# Altium schematic sheet PiJack -Microchip.SchDoc
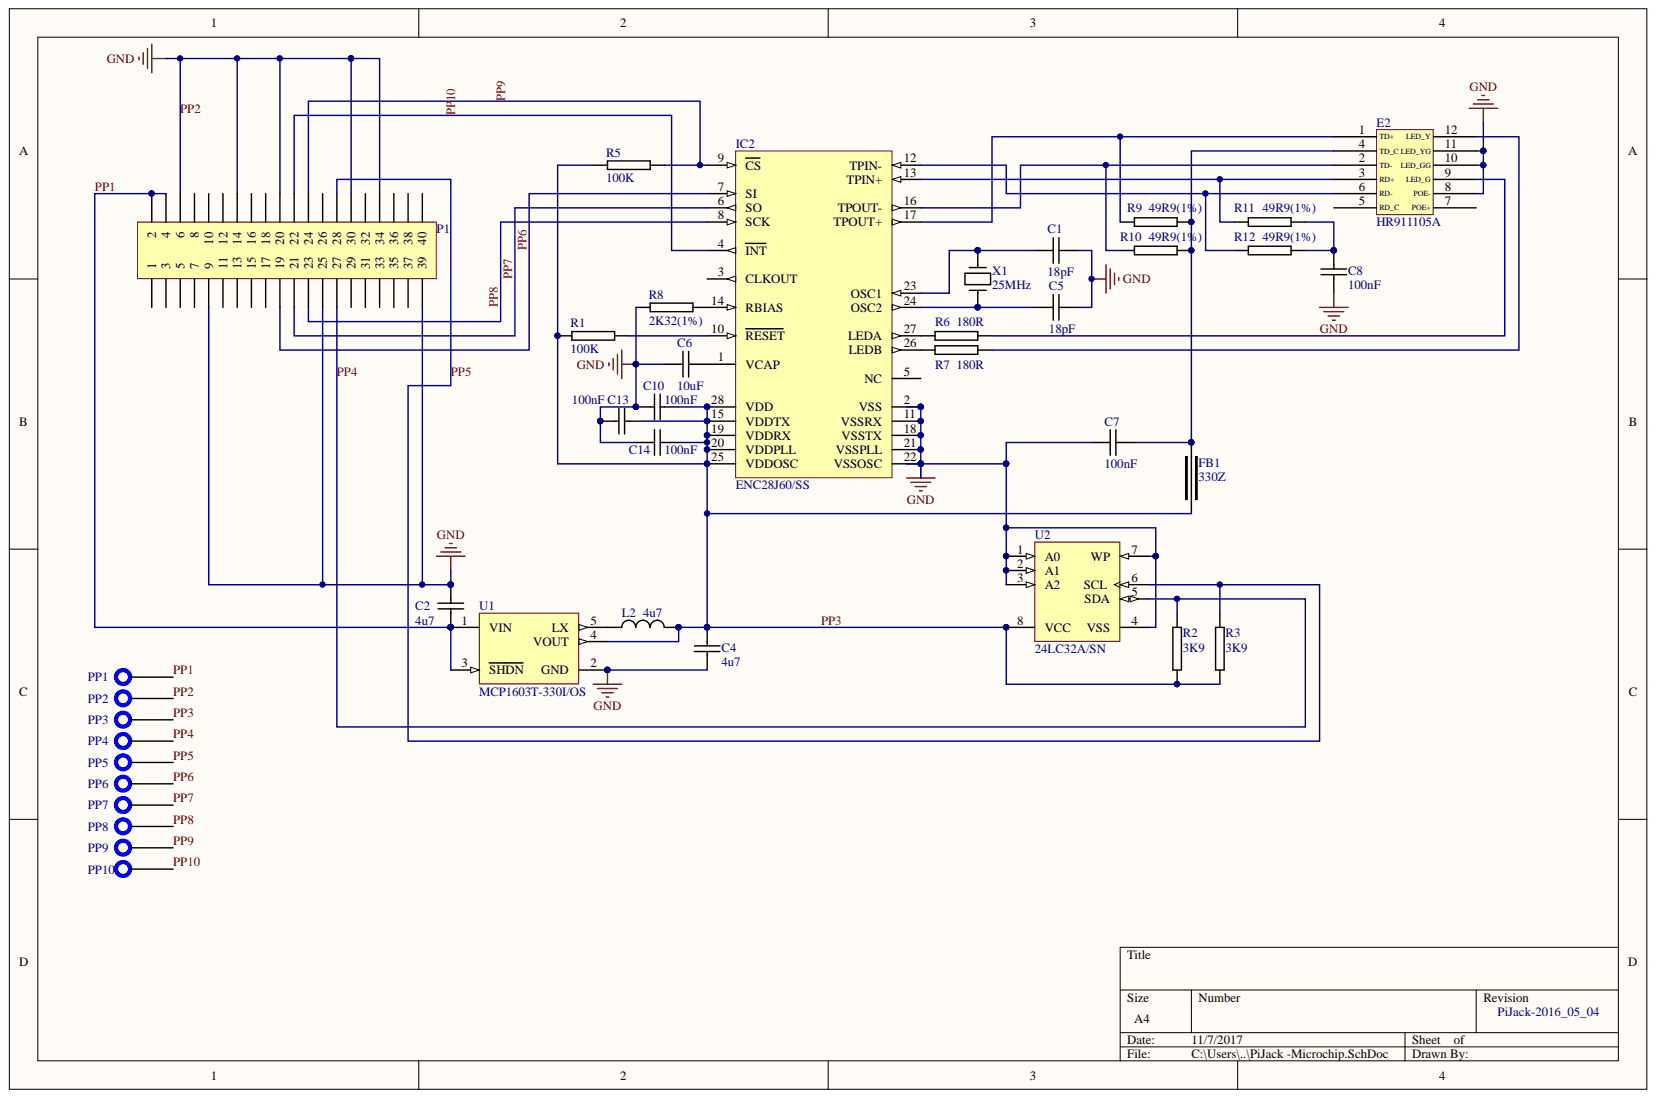
[diagram p0: PiJack -Microchip.SchDoc]

## Parts
C1  18pF  [0603C-GENERIC]
C2  4u7  [0603C-GENERIC]
C4  4u7  [0603C-GENERIC]
C5  18pF  [0603C-GENERIC]
C6  10uF  [0805C-GENERIC]
C7  100nF  [0603C-GENERIC]
C8  100nF  [0603C-GENERIC]
C10  100nF  Reel: C13  [0603C-GENERIC]
C13  100nF  Reel: C13  [0603C-GENERIC]
C14  100nF  [0603C-GENERIC]
E2  HR911105A  [HLJ6115ANL]
FB1  330Z  [0805FB-330Z]
IC2  ENC28J60/SS  [SSOP-SS28_N]
L2  4u7  [VLS2010]
P1  Header 20X2  [HDR2X20]  (value not drawn)
PP1  TEST_POINT  [TEST_POINT]  (value not drawn)
PP2  TEST_POINT  [TEST_POINT]  (value not drawn)
PP3  TEST_POINT  [TEST_POINT]  (value not drawn)
PP4  TEST_POINT  [TEST_POINT]  (value not drawn)
PP5  TEST_POINT  [TEST_POINT]  (value not drawn)
PP6  TEST_POINT  [TEST_POINT]  (value not drawn)
PP7  TEST_POINT  [TEST_POINT]  (value not drawn)
PP8  TEST_POINT  [TEST_POINT]  (value not drawn)
PP9  TEST_POINT  [TEST_POINT]  (value not drawn)
PP10  TEST_POINT  [TEST_POINT]  (value not drawn)
R1  100K  [0603R-100K]
R2  3K9  [0603R-GENERIC]
R3  3K9  [0603R-GENERIC]
R5  100K  [0603R-100K]
R6  180R  [0603R-180]
R7  180R  [0603R-180]
R8  2K32(1%)  [0603R-GENERIC]
R9  49R9(1%)  [0805R-47K]
R10  49R9(1%)  [0805R-47K]
R11  49R9(1%)  [0805R-47K]
R12  49R9(1%)  [0805R-47K]
U1  MCP1603T-330I/OS  [TSOT-OS5_N]
U2  24LC32A/SN  [SOIC-SN8_N]
X1  25MHz  [XTAL-RU49/4]

## Nets
PP1: C2.2 P1.2 P1.4 PP1.1 U1.1(VIN) U1.3(SHDN)
PP2: C1.2 C2.1 C4.2 C5.2 C6.1 C7.1 C8.2 C10.1 C13.1 C14.1 E2.8(POE-) E2.10(LED_GG) E2.11(LED_YG) IC2.2(VSS) IC2.11(VSSRX) IC2.18(VSSTX) IC2.21(VSSPLL) IC2.22(VSSOSC) P1.6 P1.9 P1.14 P1.20 P1.25 P1.30 P1.34 P1.39 PP2.1 R8.1 U1.2(GND) U2.1(A0) U2.2(A1) U2.3(A2) U2.4(VSS) U2.7(WP)
PP3: C4.1 C10.2 C13.2 C14.2 FB1.2 IC2.15(VDDTX) IC2.19(VDDRX) IC2.20(VDDPLL) IC2.25(VDDOSC) IC2.28(VDD) L2.2 PP3.1 R1.1 R2.2 R3.2 R5.1 U1.4(VOUT) U2.8(VCC)
PP4: P1.27 PP4.1 R2.1 U2.5(SDA)
PP5: P1.28 PP5.1 R3.1 U2.6(SCL)
PP6: IC2.7(SI) P1.19 PP6.1
PP7: IC2.6(SO) P1.21 PP7.1
PP8: IC2.8(SCK) P1.23 PP8.1
PP9: IC2.9(CS) P1.24 PP9.1 R5.2
PP10: IC2.4(INT) P1.22 PP10.1
NetC1_1: C1.1 IC2.23(OSC1) X1.1
NetC5_1: C5.1 IC2.24(OSC2) X1.2
NetC6_2: C6.2 IC2.1(VCAP)
NetC7_2: C7.2 E2.4(TD_C) FB1.1 R9.2 R10.2
NetC8_1: C8.1 R11.2 R12.2
NetE2_1: E2.1(TD+) IC2.17(TPOUT+) R9.1
NetE2_2: E2.2(TD-) IC2.16(TPOUT-) R10.1
NetE2_3: E2.3(RD+) IC2.13(TPIN+) R11.1
NetE2_6: E2.6(RD-) IC2.12(TPIN-) R12.1
NetE2_9: E2.9(LED_G) R6.2
NetE2_12: E2.12(LED_Y) R7.2
NetIC2_10: IC2.10(RESET) R1.2
NetIC2_14: IC2.14(RBIAS) R8.2
NetIC2_26: IC2.26(LEDB) R7.1
NetIC2_27: IC2.27(LEDA) R6.1
NetL2_1: L2.1 U1.5(LX)

Not connected: E2 5(RD_C) 7(POE+); IC2 3(CLKOUT) 5(NC); P1 1 3 5 7 8 10 11 12 13 15 16 17 18 26 29 31 32 33 35 36 37 38 40

## Library data of the parts
C1 C2 C4 C5 C7 C8 C14 = 0603C-4U7-10V-X5R-TDK: CAPACITOR 0603 CERAMIC; Manufacturer: TDK Corporation; Manufacturer Part Number: C1608X5R1A475K; Capacitance: 4.7µF; Reel: C13; Temperature Coefficient: X5R; Tolerance: ±10%; Voltage - Rated: 10V; Category: Capacitors; Component Kind: Standard; Features: General Purpose; Lead Spacing: -; Library Name: SMD Capacitors 0603.SchLib; Mounting Type: Surface Mount, MLCC; Operating Temperature: -55°C ~ 85°C; Package / Case: 0603 (1608 metric); Packaging: Tape & Reel (TR); RoHS: RoHS Compliant; Supplier: Digi-Key; Supplier 1: Digi-Key; Supplier Part Number: 445-5170-2-ND; Supplier Part Number 1: 445-5170-2-ND; Thickness: 0.80mm
C6 = 0805C-10UF-16V-X5R-TDK: CAPACITOR 0805 CERAMIC; Manufacturer: TDK Corporation; Manufacturer Part Number: C2012X5R1C106M; REEL: C63; Component Kind: Standard; Library Name: SMD Capacitors 0805.SchLib; Supplier 1: Digi-Key; Supplier Part Number 1: 445-4115-2-ND
C10 C13 = 0603C-4U7-10V-X5R-TDK: CAPACITOR 0603 CERAMIC; Manufacturer: TDK Corporation; Manufacturer Part Number: C1608X5R1A475K; Capacitance: 4.7µF; Temperature Coefficient: X5R; Tolerance: ±10%; Voltage - Rated: 10V; Category: Capacitors; Component Kind: Standard; Features: General Purpose; Lead Spacing: -; Library Name: SMD Capacitors 0603.SchLib; Mounting Type: Surface Mount, MLCC; Operating Temperature: -55°C ~ 85°C; Package / Case: 0603 (1608 metric); Packaging: Tape & Reel (TR); RoHS: RoHS Compliant; Supplier: Digi-Key; Supplier 1: Digi-Key; Supplier Part Number: 445-5170-2-ND; Supplier Part Number 1: 445-5170-2-ND; Thickness: 0.80mm
E2 = HLJ-6115ANL: Component Kind: Standard; Library Name: PiJack.SchLib
FB1 = 0805FB-330Z: FERRITE BEAD 330 OHM 2.5A; Reel: F4; Component Kind: Standard; Library Name: SMD Magnetics.SchLib
IC2 = ENC28J60/SS: Stand-Alone Ethernet Controller with SPI Interface, 28-Pin SSOP; Code_IPC: SOP65P780-28; Code_JEDEC: MS-150; Component Kind: Standard; ComponentLink1Description: Datasheet; ComponentLink1URL: http://ww1.microchip.com/downloads/en/DeviceDoc/39662c.pdf; ComponentLink2Description: Packaging Specification; ComponentLink2URL: http://www.microchip.com/stellent/groups/techpub_sg/documents/packagingspec/en012702.pdf; ComponentLink3Description: Microchip.com Home; ComponentLink3URL: http://www.microchip.com/; DatasheetVersion: RevC, Jan-2008; Library Name: Microchip Interface.IntLib; PackageDescription: 28-Lead Plastic Shrink Small Outline (SS) - 5.3mm Body [SSOP]; PackageReference: SSOP-SS28; PackageVersion: revBB, Aug-2009; Published: 29-Jan-2009; Supplier 1: Digi-Key; Supplier 2: Farnell; Supplier Part Number 1: ENC28J60/SS-ND; Supplier Part Number 2: 1084620; Publisher: Altium Limited
L2 = 4U7-VLS3012-TDK: TDK-VLS3012-4u7; Manufacturer: TDK Corporation; Manufacturer Part Number: VLS3012T-4R7M1R0; Reel: L3; Component Kind: Standard; Supplier 1: Digi-Key; Supplier Part Number 1: 445-3686-6-ND; Library Name: SMD Magnetics.SchLib
P1 = Header 20X2: Header, 20-Pin, Dual row; Component Kind: Standard; LatestRevisionDate: 17-Jul-2002; LatestRevisionNote: Re-released for DXP Platform.; Library Name: Miscellaneous Connectors.IntLib; Publisher: Altium Limited
R1 R5 = 0603R-100K: GENERIC RESISTOR 0603; Manufacturer: Stackpole Electronics Inc; Manufacturer Part Number: RMCF 1/16 100K 1% R; Power (Watts): 0.1W, 1/10W; REEL: R47; Temperature Coefficient: ±100ppm/°C; Tolerance: ±1%; Case: 0603 (1608 metric); Category: Resistors; Component Kind: Standard; Composition: Thick Film; Lead Style: Surface Mount (SMD - SMT); Library Name: SMD Resistors 0603.SchLib; Packaging: Cut Tape (CT); Resistance In Ohms: 100K; RoHS: RoHS Compliant; Supplier: Digi-Key; Supplier 1: Digi-Key; Supplier Part Number: RMCF1/16100KFRCT-ND; Supplier Part Number 1: RMCF0603FT100KTR-ND
R2 R3 = 0603R-3K6: GENERIC RESISTOR 0603; Manufacturer: Stackpole Electronics Inc; Manufacturer Part Number: RMC 1/16 3.6K 5% R; Component Kind: Standard; Library Name: SMD Resistors 0603.SchLib; Supplier 1: Digi-Key; Supplier Part Number 1: RMC1/163.6KJR-ND
R6 R7 = 0603R-180: GENERIC RESISTOR 0603; Manufacturer: Stackpole Electronics Inc; Manufacturer Part Number: RMC 1/16 180 1% R; Power (Watts): 0.1W, 1/10W; Resistance In Ohms: 180; Temperature Coefficient: ±100ppm/°C; Tolerance: ±1%; Case: 0603 (1608 metric); Category: Resistors; Component Kind: Standard; Composition: Thick Film; Lead Style: Surface Mount (SMD - SMT); Library Name: SMD Resistors 0603.SchLib; Packaging: Tape & Reel (TR); RoHS: RoHS Compliant; Supplier: Digi-Key; Supplier 1: Digi-Key; Supplier Part Number: RMC1/16180FR-ND; Supplier Part Number 1: RMC1/16180FR-ND
R8 = 0603R-2K49: GENERIC RESISTOR 0603; Manufacturer: Stackpole Electronics Inc; Manufacturer Part Number: RMCF 1/16 2.49K 1% R; Power (Watts): 0.1W, 1/10W; REEL: R83; Resistance In Ohms: 2.49K; Temperature Coefficient: ±100ppm/°C; Case: 0603 (1608 metric); Category: Resistors; Component Kind: Standard; Composition: Thick Film; Lead Style: Surface Mount (SMD - SMT); Library Name: SMD Resistors 0603.SchLib; Packaging: Tape & Reel (TR); RoHS: RoHS Compliant; Supplier: Digi-Key; Supplier 1: Digi-Key; Supplier Part Number: RMCF1/162.49KFRTR-ND; Supplier Part Number 1: RMCF0603FT2K49TR-ND; Tolerance: ±1%
R9-R12 = 0603R-49R9: GENERIC RESISTOR 0603; Component Kind: Standard; Library Name: SMD Resistors 0603.SchLib; Supplier 1: Digi-Key; Supplier Part Number 1: RMCF0603FT49R9TR-ND
U1 = MCP1603T-330I/OS: 500mA Synchronous Buck Regulator, 3.3V, 2.0 MHz, 5-Pin TSOT, Industrial Temperature, Tape and Reel; Component Kind: Standard; ComponentLink1Description: Datasheet; ComponentLink1URL: http://ww1.microchip.com/downloads/en/DeviceDoc/22042a.pdf; ComponentLink2Description: Packaging Specification; ComponentLink2URL: http://www.microchip.com/stellent/groups/techpub_sg/documents/packagingspec/en012702.pdf; DatasheetVersion: revA, May-2007; Library Name: Microchip Power Mgt Switching Regulator.IntLib; PackageDescription: 5-Lead Plastic Small Outline Transistor (OS) [TSOT]; PackageReference: TSOT-OS5; PackageVersion: revBB, Aug-2009; Published: 29-Jan-2009; Supplier 1: Digi-Key; Supplier 2: Digi-Key; Supplier 3: Digi-Key; Supplier 4: Farnell; Supplier Part Number 1: MCP1603T-330I/OSTR-ND; Supplier Part Number 2: MCP1603T-330I/OSCT-ND; Supplier Part Number 3: MCP1603T-330I/OSDKR-ND; Supplier Part Number 4: 1439373; Publisher: Altium Limited
U2 = 24LC32A/SN: 32Kbit, 400kHz, 2.5V, I2C Serial EEPROM, 8-Pin SOIC 150mil, Commercial Temperature; Code_IPC: SOIC127P600-8; Code_JEDEC: MS-012; Component Kind: Standard; ComponentLink1Description: Datasheet; ComponentLink1URL: http://ww1.microchip.com/downloads/en/DeviceDoc/21713J.pdf; ComponentLink2Description: Packaging Specification; ComponentLink2URL: http://www.microchip.com/stellent/groups/techpub_sg/documents/packagingspec/en012702.pdf; ComponentLink3Description: Microchip.com Home; ComponentLink3URL: http://www.microchip.com/; DatasheetVersion: revJ, Feb-2009; Library Name: Microchip Memory I2C Serial EEPROM.IntLib; PackageDescription: 8-Lead Plastic Small Outline (SN) - Narrow, 3.90mm Body [SOIC]; PackageReference: SOIC-SN8; PackageVersion: revBB, Aug-2009; Published: 29-Jan-2009; Supplier 1: Digi-Key; Supplier 2: Farnell; Supplier Part Number 1: 24LC32A/SN-ND; Supplier Part Number 2: 9758003; Publisher: Altium Limited
X1 = 16MC-HC49US: 16MHZ CRSYTAL HC49US; Component Kind: Standard; Library Name: Crystals.SchLib; Supplier 1: Mouser; Supplier Part Number 1: 815-ABLS-16.0M-T
PP1-PP10 = TEST_POINT: Component Kind: Standard; Library Name: PiJack.SchLib
